# Altium schematic sheet PIC.SchDoc
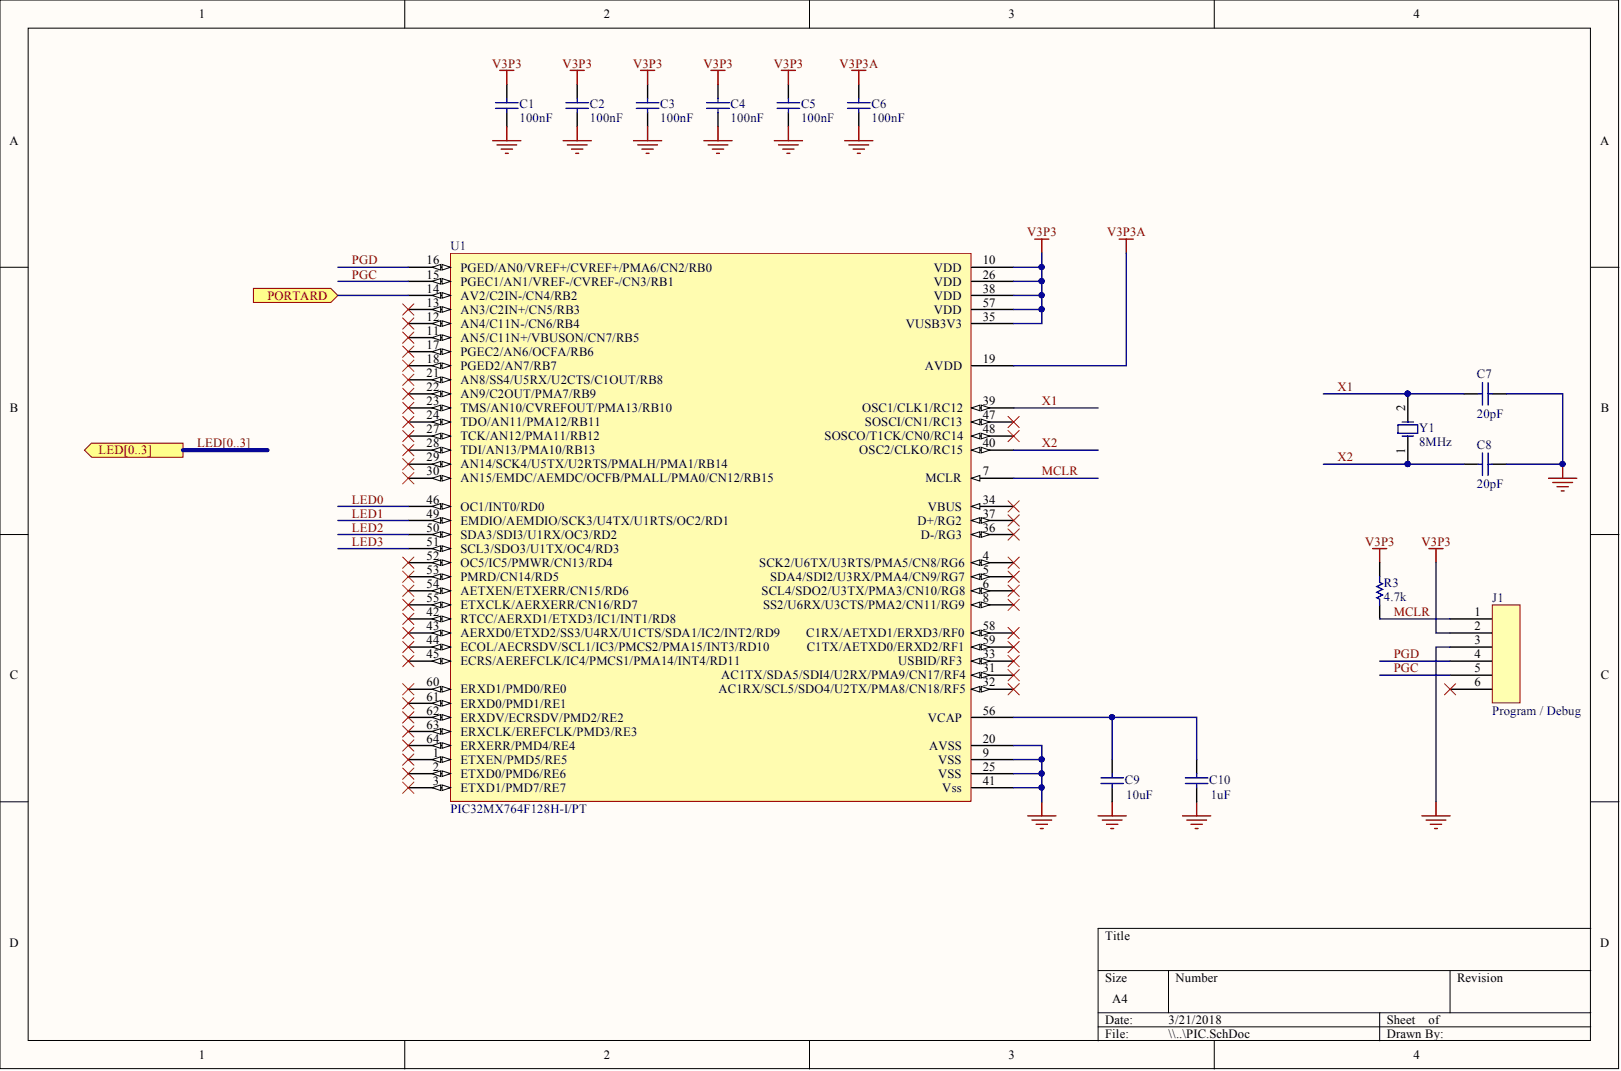
[diagram p0: PIC.SchDoc]

## Parts
C1  100nF  [CAPC1608N]
C2  100nF  [CAPC1608N]
C3  100nF  [CAPC1608N]
C4  100nF  [CAPC1608N]
C5  100nF  [CAPC1608N]
C6  100nF  [CAPC1608N]
C7  20pF  [CAPC1608N]
C8  20pF  [CAPC1608N]
C9  10uF  [CAPC2012N]
C10  1uF  [CAPC1608N]
J1  Program / Debug  [RJ12_90]
R3  4.7k  [RESC1608N]
U1  PIC32MX764F128H-I/PT  [QFP50P1200X1200X120-64]
Y1  8MHz  [HC49/4H SMX Crystal]

## Ports
LED0, LED1, LED2, LED3, LED[0..3], PORTARD

## Nets
GND: C1.1 C2.1 C3.1 C4.1 C5.1 C6.1 C7.2 C8.2 C9.1 C10.1 J1.3 U1.9(VSS) U1.20(AVSS) U1.25(VSS) U1.41(Vss)
V3P3: C1.2 C2.2 C3.2 C4.2 C5.2 J1.2 R3.2 U1.10(VDD) U1.26(VDD) U1.35(VUSB3V3) U1.38(VDD) U1.57(VDD)
V3P3A: C6.2 U1.19(AVDD)
LED0: U1.46(OC1/INT0/RD0) ; port LED[0..3]  [also labelled LED]
LED1: U1.49(EMDIO/AEMDIO/SCK3/U4TX/U1RTS/OC2/RD1) ; port LED[0..3]  [also labelled LED]
LED2: U1.50(SDA3/SDI3/U1RX/OC3/RD2) ; port LED[0..3]  [also labelled LED]
LED3: U1.51(SCL3/SDO3/U1TX/OC4/RD3) ; port LED[0..3]  [also labelled LED]
MCLR: J1.1 R3.1 U1.7(MCLR)
PGC: J1.5 U1.15(PGEC1/AN1/VREF-/CVREF-/CN3/RB1)
PGD: J1.4 U1.16(PGED/AN0/VREF+/CVREF+/PMA6/CN2/RB0)
PORTARD: U1.14(AV2/C2IN-/CN4/RB2) ; port PORTARD
X1: C7.1 U1.39(OSC1/CLK1/RC12) Y1.2
X2: C8.1 U1.40(OSC2/CLKO/RC15) Y1.1
NetC9_2: C9.2 C10.2 U1.56(VCAP)

Not connected: J1 6; U1 1(ETXEN/PMD5/RE5) 2(ETXD0/PMD6/RE6) 3(ETXD1/PMD7/RE7) 4(SCK2/U6TX/U3RTS/PMA5/CN8/RG6) 5(SDA4/SDI2/U3RX/PMA4/CN9/RG7) 6(SCL4/SDO2/U3TX/PMA3/CN10/RG8) 8(SS2/U6RX/U3CTS/PMA2/CN11/RG9) 11(AN5/C11N+/VBUSON/CN7/RB5) 12(AN4/C11N-/CN6/RB4) 13(AN3/C2IN+/CN5/RB3) 17(PGEC2/AN6/OCFA/RB6) 18(PGED2/AN7/RB7) 21(AN8/SS4/U5RX/U2CTS/C1OUT/RB8) 22(AN9/C2OUT/PMA7/RB9) 23(TMS/AN10/CVREFOUT/PMA13/RB10) 24(TDO/AN11/PMA12/RB11) 27(TCK/AN12/PMA11/RB12) 28(TDI/AN13/PMA10/RB13) 29(AN14/SCK4/U5TX/U2RTS/PMALH/PMA1/RB14) 30(AN15/EMDC/AEMDC/OCFB/PMALL/PMA0/CN12/RB15) 31(AC1TX/SDA5/SDI4/U2RX/PMA9/CN17/RF4) 32(AC1RX/SCL5/SDO4/U2TX/PMA8/CN18/RF5) 33(USBID/RF3) 34(VBUS) 36(D-/RG3) 37(D+/RG2) 42(RTCC/AERXD1/ETXD3/IC1/INT1/RD8) 43(AERXD0/ETXD2/SS3/U4RX/U1CTS/SDA1/IC2/INT2/RD9) 44(ECOL/AECRSDV/SCL1/IC3/PMCS2/PMA15/INT3/RD10) 45(ECRS/AEREFCLK/IC4/PMCS1/PMA14/INT4/RD11) 47(SOSCI/CN1/RC13) 48(SOSCO/T1CK/CN0/RC14) 52(OC5/IC5/PMWR/CN13/RD4) 53(PMRD/CN14/RD5) 54(AETXEN/ETXERR/CN15/RD6) 55(ETXCLK/AERXERR/CN16/RD7) 58(C1RX/AETXD1/ERXD3/RF0) 59(C1TX/AETXD0/ERXD2/RF1) 60(ERXD1/PMD0/RE0) 61(ERXD0/PMD1/RE1) 62(ERXDV/ECRSDV/PMD2/RE2) 63(ERXCLK/EREFCLK/PMD3/RE3) 64(ERXERR/PMD4/RE4)

## Library data of the parts
C1-C8 = Cap: Capacitor; Precision: 50V X5R; Component Kind: Standard; Library Name: Miscellaneous Devices.IntLib; Signal Integrity: Cap; Simulation: CAP
  C1-C6: Valeur: 100nF
  C7 C8: Valeur: 20pF
C9 = Cap: Capacitor; Fabricant: TDK; Fournisseur: Farnell; Precision: 6.3V X5R 10uF; Ref.Fabricant: C2012X5R0J106M/1.25; Ref.Fournisseur: 2309029; Component Kind: Standard; Library Name: Miscellaneous Devices.IntLib; Signal Integrity: Cap; Simulation: CAP; Valeur: 10uF
C10 = Cap: Capacitor; Precision: 6.3V X5R; Component Kind: Standard; Library Name: Miscellaneous Devices.IntLib; Published: 8-Jun-2000; Signal Integrity: Cap; Simulation: CAP; Valeur: 1uF
J1 = Program / Debug: Fabricant: Tyco; Fournisseur: Farnell; Ref.Fabricant: 1-215876-3; Ref.Fournisseur: 1773039; Component Kind: Standard; Library Name: Schlib1.SchLib
R3 = Res1: Resistor; Precision: 1%; Component Kind: Standard; Library Name: Miscellaneous Devices.IntLib; Signal Integrity: Res; Simulation: RESISTOR; Valeur: 4.7k
U1 = PIC32MX764F128H-I/PT: Fabricant: Microchip; Fournisseur: Farnell; Ref.Fournisseur: 1971889; Component Kind: Standard; Description: PIC32MX764F128H-I/PT; Library Name: Schlib1.SchLib; Ref.Fabricant: PIC32MX764F128H-I/PT
Y1 = XTAL: Crystal Oscillator; Fabricant: FOX ELECTRONICS; Fournisseur: Farnell; Ref.Fabricant: FOXSDLF/080-20; Ref.Fournisseur: 2063972; Component Kind: Standard; Library Name: Miscellaneous Devices.IntLib; Simulation: XTAL; Valeur: 8MHz
